# Altium schematic sheet Power.SchDoc
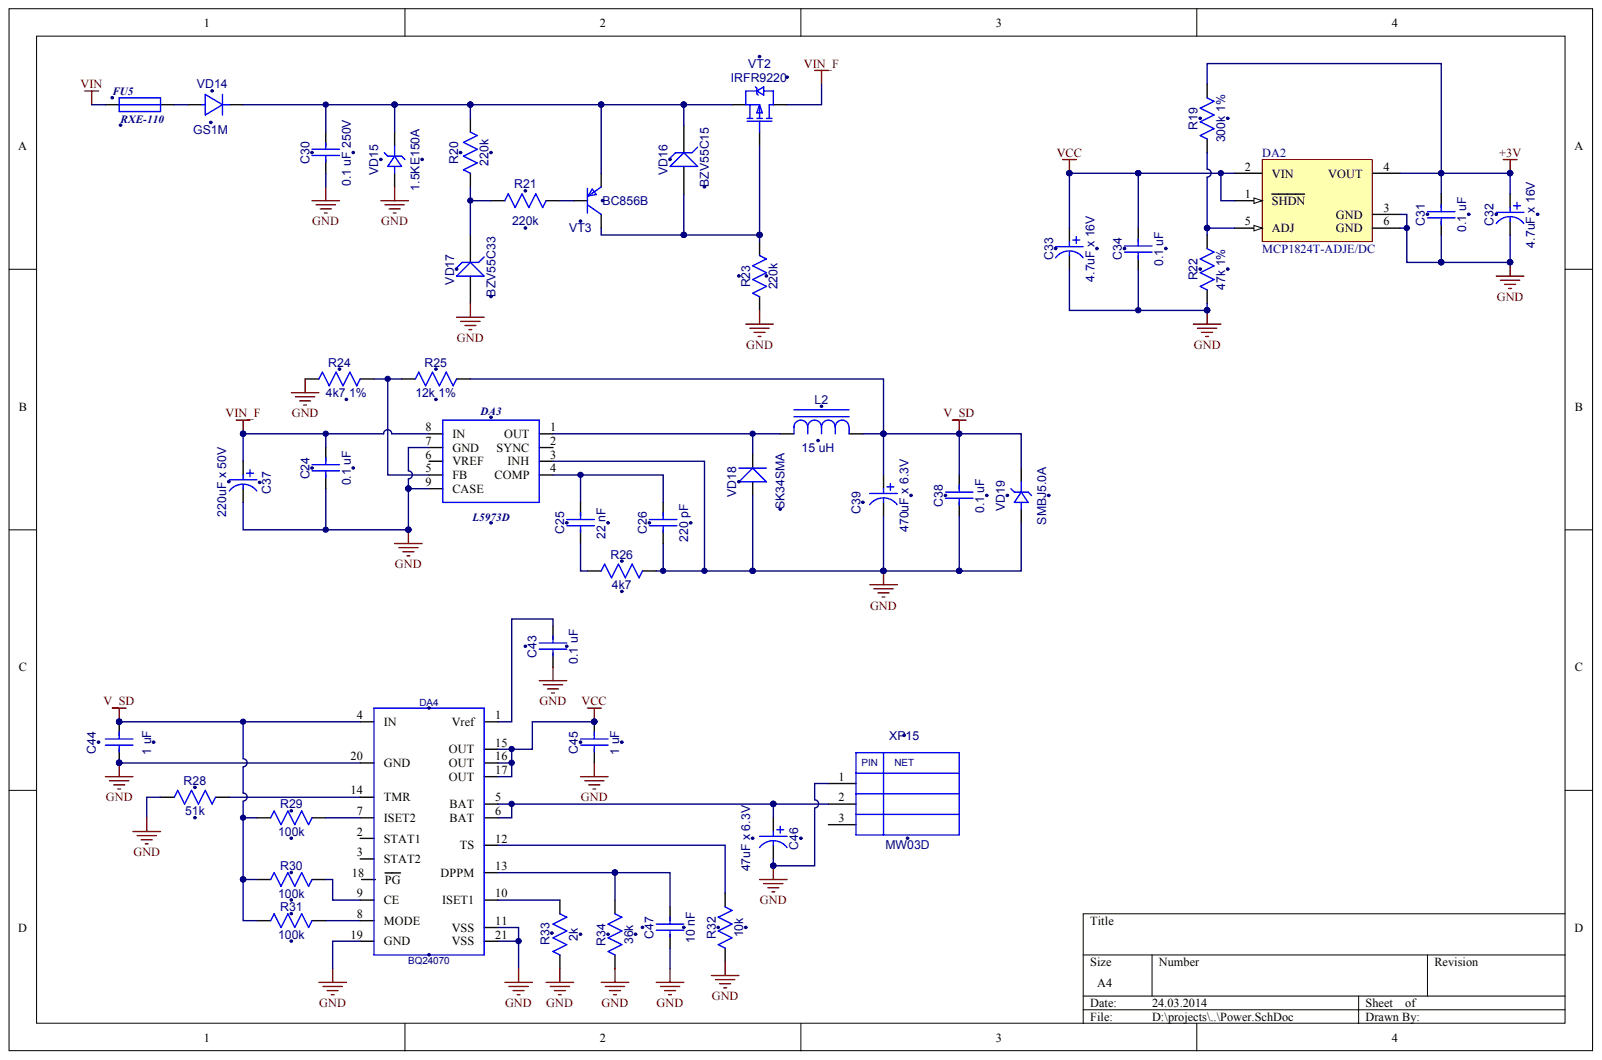
[diagram p0: Power.SchDoc]

## Parts
C24  0.1 uF
C25  22 nF
C26  220 pF
C30  0.1 uF 250V
C31  0.1 uF
C32  4.7uF x 16V
C33  4.7uF x 16V
C34  0.1 uF
C37  220uF x 50V
C38  0.1 uF
C39  470uF x 6.3V
C43  0.1 uF
C44  1 uF
C45  1 uF
C46  47uF x 6.3V
C47  10 nF
DA2  MCP1824T-ADJE/DC
DA3  L5973D
DA4  BQ24070
FU5  RXE-110
L2  15 uH
R19  300k 1%
R20  220k
R21  220k
R22  47k 1%
R23  220k
R24  4k7 1%
R25  12k 1%
R26  4k7
R28  51k
R29  100k
R30  100k
R31  100k
R32  10k
R33  2k
R34  36k
VD14  GS1M
VD15  1.5KE150A
VD16  BZV55C15
VD17  BZV55C33
VD18  SK34SMA
VD19  SMBJ5.0A
VT2  IRFR9220
VT3  BC856B
XP15  MW03D

## Nets
+3V: C31.2 C32.1 DA2.4(VOUT) R19.2
GND: C24.2 C26.2 C30.2 C31.1 C32.2 C33.2 C34.1 C37.1 C38.2 C39.2 C43.2 C44.2 C45.2 C46.2 C47.2 DA2.3(GND) DA2.6(GND) DA3.3(INH) DA3.7(GND) DA3.9(CASE) DA4.11(VSS) DA4.19(GND) DA4.20(GND) DA4.21(VSS) R22.1 R23.2 R24.1 R26.2 R28.1 R32.1 R33.1 R34.1 VD15.1 VD17.1 VD18.1 VD19.1 XP15.1
V_SD: C38.1 C39.1 C44.1 DA4.4(IN) L2.2 R25.2 R29.1 R30.1 R31.1 VD19.2
VCC: C33.1 C34.2 C45.1 DA2.1(SHDN) DA2.2(VIN) DA4.15(OUT) DA4.16(OUT) DA4.17(OUT)
VIN: FU5.1
VIN_F: C24.1 C37.2 DA3.8(IN) VT2.3
NetC25_1: C25.1 C26.1 DA3.4(COMP)
NetC25_2: C25.2 R26.1
NetC30_1: C30.1 R20.2 VD14.2 VD15.2 VD16.2 VT2.2 VT3.2
NetC43_1: C43.1 DA4.1(Vref)
NetC46_1: C46.1 DA4.5(BAT) DA4.6(BAT) XP15.2
NetC47_1: C47.1 DA4.13(DPPM) R34.2
NetDA2_5: DA2.5(ADJ) R19.1 R22.2
NetDA3_1: DA3.1(OUT) L2.1 VD18.2
NetDA3_5: DA3.5(FB) R24.2 R25.1
NetDA4_7: DA4.7(ISET2) R29.2
NetDA4_8: DA4.8(MODE) R31.2
NetDA4_9: DA4.9(CE) R30.2
NetDA4_10: DA4.10(ISET1) R33.2
NetDA4_12: DA4.12(TS) R32.2
NetDA4_14: DA4.14(TMR) R28.2
NetFU5_2: FU5.2 VD14.1
NetR20_1: R20.1 R21.1 VD17.2
NetR21_2: R21.2 VT3.1
NetR23_1: R23.1 VD16.1 VT2.1 VT3.3

Not connected: DA3 2(SYNC) 6(VREF); DA4 2(STAT1) 3(STAT2) 18(PG); XP15 3

## Library data of the parts
VD14 VD18 = (no symbol name): Value: {Value}
VD16 VD17 = (no symbol name): OriginalName: BZX84C10LT1; ComponentField6: Pin 2 is not connected and not drawn.; ComponentField7: Published 29-Sep-2000
  VD16: Value: BZV55C15
  VD17: Value: BZV55C33
VT2 = (no symbol name): OriginalName: IRLML5103; ComponentField7: Published 8-Jun-2000; Value: IRFR9220
VT3 = (no symbol name): OriginalName: BC846BLT1; ComponentField7: Published 29-Sep-2000; Value: BC856B
C24-C26 C30-C34 C37-C39 C43-C47 DA2-DA4 FU5 L2 R19-R26 R28-R34 VD15 VD19 XP15 = (no symbol name)
  C24 C31 C34 C38 C43: Value: 0.1 uF
  C25: Value: 22 nF
  C26: Value: 220 pF
  C30: Value: 0.1 uF 250V
  C32 C33: Value: 4.7uF x 16V
  C37: Value: 220uF x 50V
  C39: Value: 470uF x 6.3V
  C44 C45: Value: 1 uF
  C46: Value: 47uF x 6.3V
  C47: Value: 10 nF
  DA2: Published: 29-Jan-2009; DatasheetVersion: revA, Aug-2007; PackageVersion: revAW, Oct-2008; PackageReference: SOT-223-DC5; PackageDescription: 5-Lead Plastic Small Outline Transistor (DC) [SOT-223]; ComponentLink1Description: Manufacturer Link; ComponentLink1URL: http://www.microchip.com; ComponentLink2Description: Datasheet; ComponentLink2URL: http://ww1.microchip.com/downloads/en/DeviceDoc/22070a.pdf; ComponentLink3Description: Packaging Specification; ComponentLink3URL: http://ww1.microchip.com/downloads/en/PackagingSpec/00049AW.pdf; Publisher: Altium Limited
  DA4 FU5 VD19 XP15: Value: *
  L2: Value: 15 uH
  R19: Value: 300k 1%
  R20 R21 R23: Value: 220k
  R22: Value: 47k 1%
  R24: Value: 4k7 1%
  R25: Value: 12k 1%
  R26: Value: 4k7
  R28: Value: 51k
  R29-R31: Value: 100k
  R32: Value: 10k
  R33: Value: 2k
  R34: Value: 36k
  VD15: Value: 1.5KE150A
